# Altium schematic sheet InclinometerDisplay.SchDoc
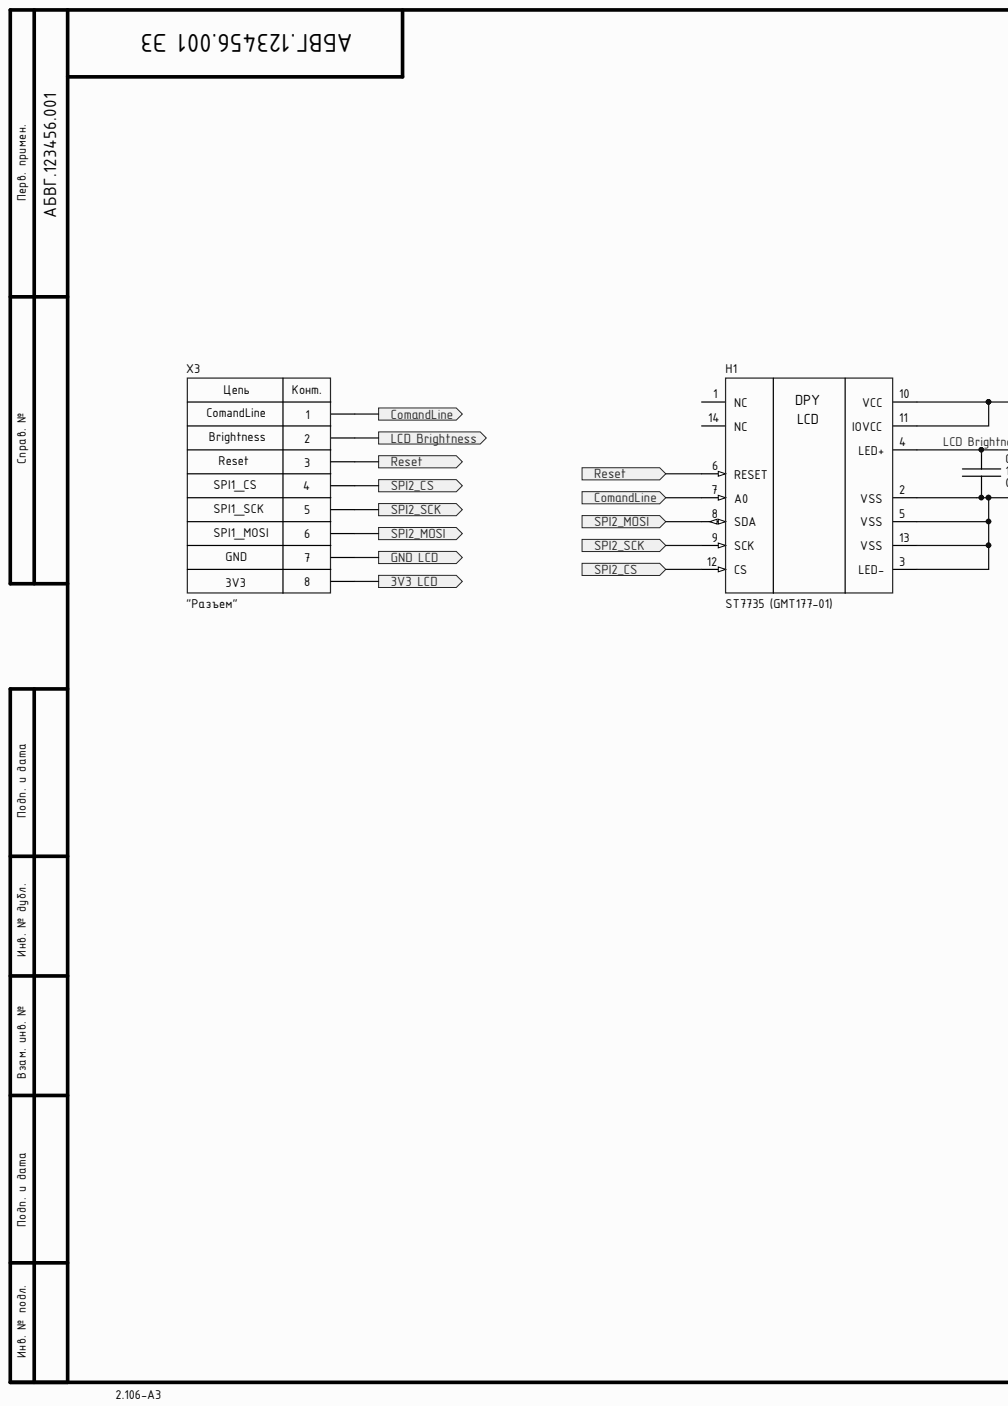
[diagram p0: InclinometerDisplay.SchDoc, left]
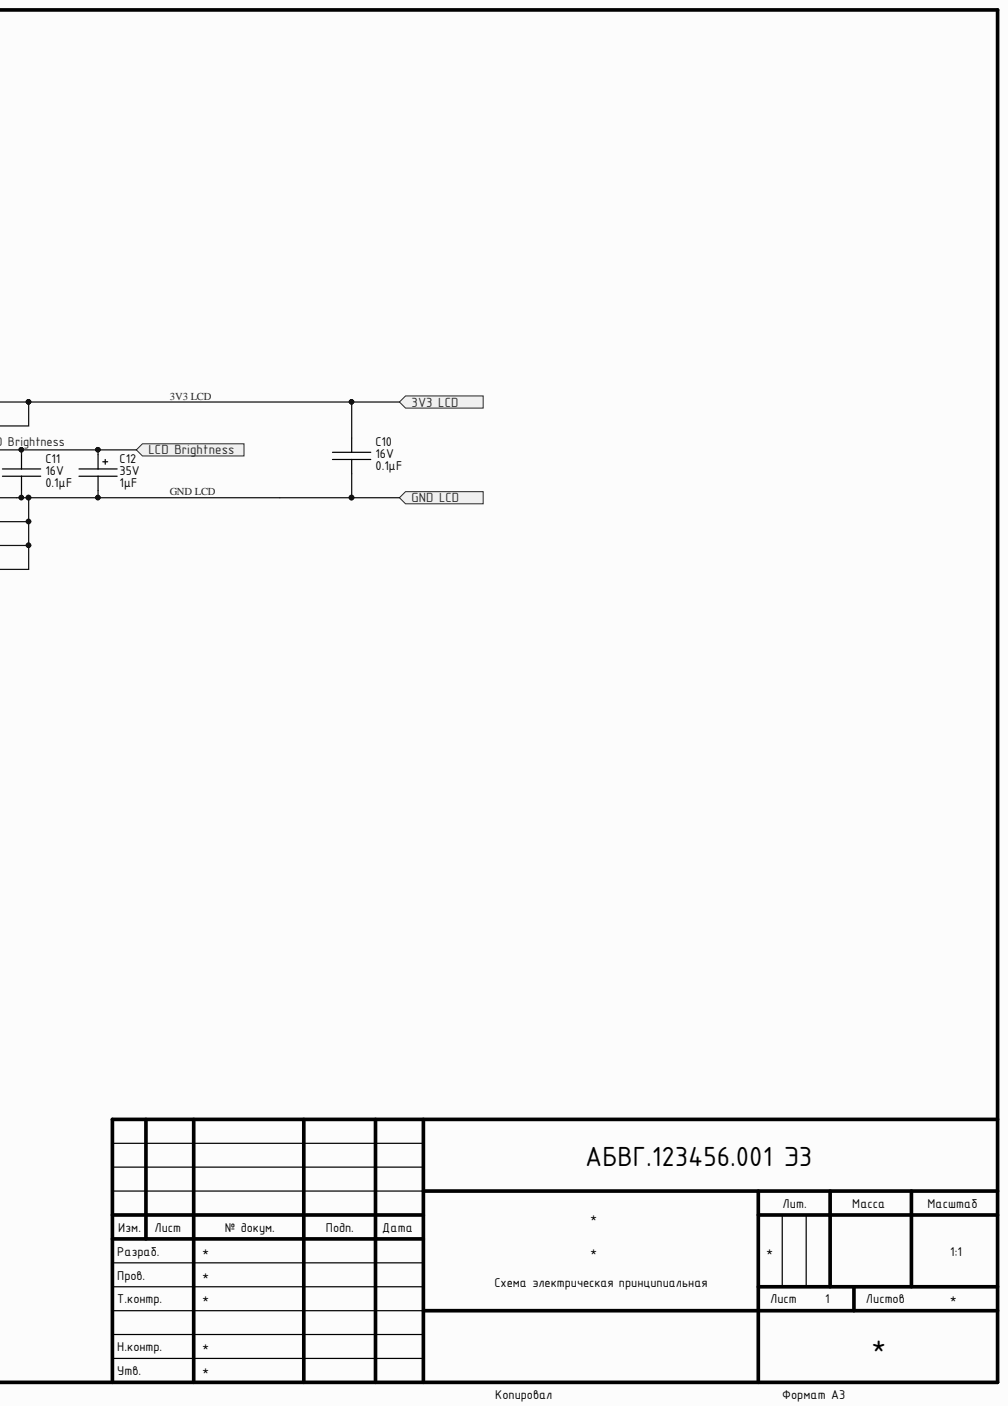
[diagram p1: InclinometerDisplay.SchDoc, right]

## Parts
C10  value 0.1μF  Voltage: 16V  [CAP: 0603]  (hidden comment: Ceramic capacitor, 0.1μF, X7R, ±10%, 16V, 0603, -55...+125°C)
C11  value 0.1μF  Voltage: 16V  [CAP: 0603]  (hidden comment: Ceramic capacitor, 0.1μF, X7R, ±10%, 16V, 0603, -55...+125°C)
C12  value 1μF  Voltage: 35V  [CAP: TANTAL-A]  (hidden comment: Tantalum capacitor, 1μF, 35V, ±10%, 7.5Ohm, case A (3216), -55...+125°C)
H1  ST7735 (GMT177-01)  [ST7735 DISPLAY]
X3  CommentText: "Разъем"  [CON: PLS-8]  (hidden comment: Connector, 8pin, 2.54mm, 500VAC, 3A)

## Ports
3V3 LCD, ComandLine, GND LCD, LCD Brightness, Reset, SPI2_CS, SPI2_MOSI, SPI2_SCK

## Nets
3V3 LCD: C10.2 H1.10(VCC) H1.11(IOVCC) X3.8 ; port 3V3 LCD
ComandLine: H1.7(A0) X3.1 ; port ComandLine
GND LCD: C10.1 C11.1 C12.2 H1.3(LED-) H1.5(VSS) H1.13(VSS) X3.7 ; port GND LCD
LCD Brightness: C11.2 C12.1 H1.4(LED+) X3.2 ; port LCD Brightness
Reset: H1.6(RESET) X3.3 ; port Reset
SPI2_CS: H1.12(CS) X3.4 ; port SPI2_CS
SPI2_MOSI: H1.8(SDA) X3.6 ; port SPI2_MOSI
SPI2_SCK: H1.9(SCK) X3.5 ; port SPI2_SCK

Not connected: H1 1(NC) 2(VSS) 14(NC)

## Library data of the parts
C10 C11 = Ceramic_0603: Manufacturer: Murata Electronics; PartNumber: GRM188R71C104KA01D; Component Kind: Standard; HelpURL: https://www.digikey.com/product-detail/en/murata-electronics/GRM188R71C104KA01D/490-1532-2-ND/587060; Library Name: Capacitor.IntLib; Package: 0603; Temperature: -55...+125°C; Tolerance: ±10%; TypeCapacitor: Ceramic capacitor; TypeDielectric: X7R; ValueManufacturerPartNumber: Ceramic capacitor, 0.1μF, X7R, ±10%, 16V, 0603, -55...+125°C
C12 = Tantal_A: Manufacturer: AVX; PartNumber: TAJA105K035RNJ; Component Kind: Standard; HelpURL: https://www.digikey.com/product-detail/en/avx-corporation/TAJA105K035RNJ/478-1651-2-ND/563759; Impedance: 7.5Ohm; Library Name: Capacitor.IntLib; Package: case A (3216); Temperature: -55...+125°C; Tolerance: ±10%; TypeCapacitor: Tantalum capacitor; ValueManufacturerPartNumber: Tantalum capacitor, 1μF, 35V, ±10%, 7.5Ohm, case A (3216), -55...+125°C
H1 = ST7735 DISPLAY: Manufacture: Sitronix; Component Kind: Standard; Help URL: https://pdf1.alldatasheetru.com/datasheet-pdf/view/326213/SITRONIX/ST7735.html; Model: ST7735 (GMT177-01); Library Name: Displays.IntLib
X3 = PLS-8: Manufacturer: Connfly; Component Kind: Standard; CurrentMax: 3A; HelpURL: https://www.chipdip.ru/product/pls-8-ds1021-1-8sf112; Library Name: Connector.IntLib; Multichannel: 8pin; PartNumber: PLS-8; Pitch: 2.54mm; TypeConnector: Connector; ValueManufacturerPartNumber: Connector, 8pin, 2.54mm, 500VAC, 3A; VoltageMax: 500VAC
